# Altium schematic sheet BREEZE Pro TOF V1.SchDoc
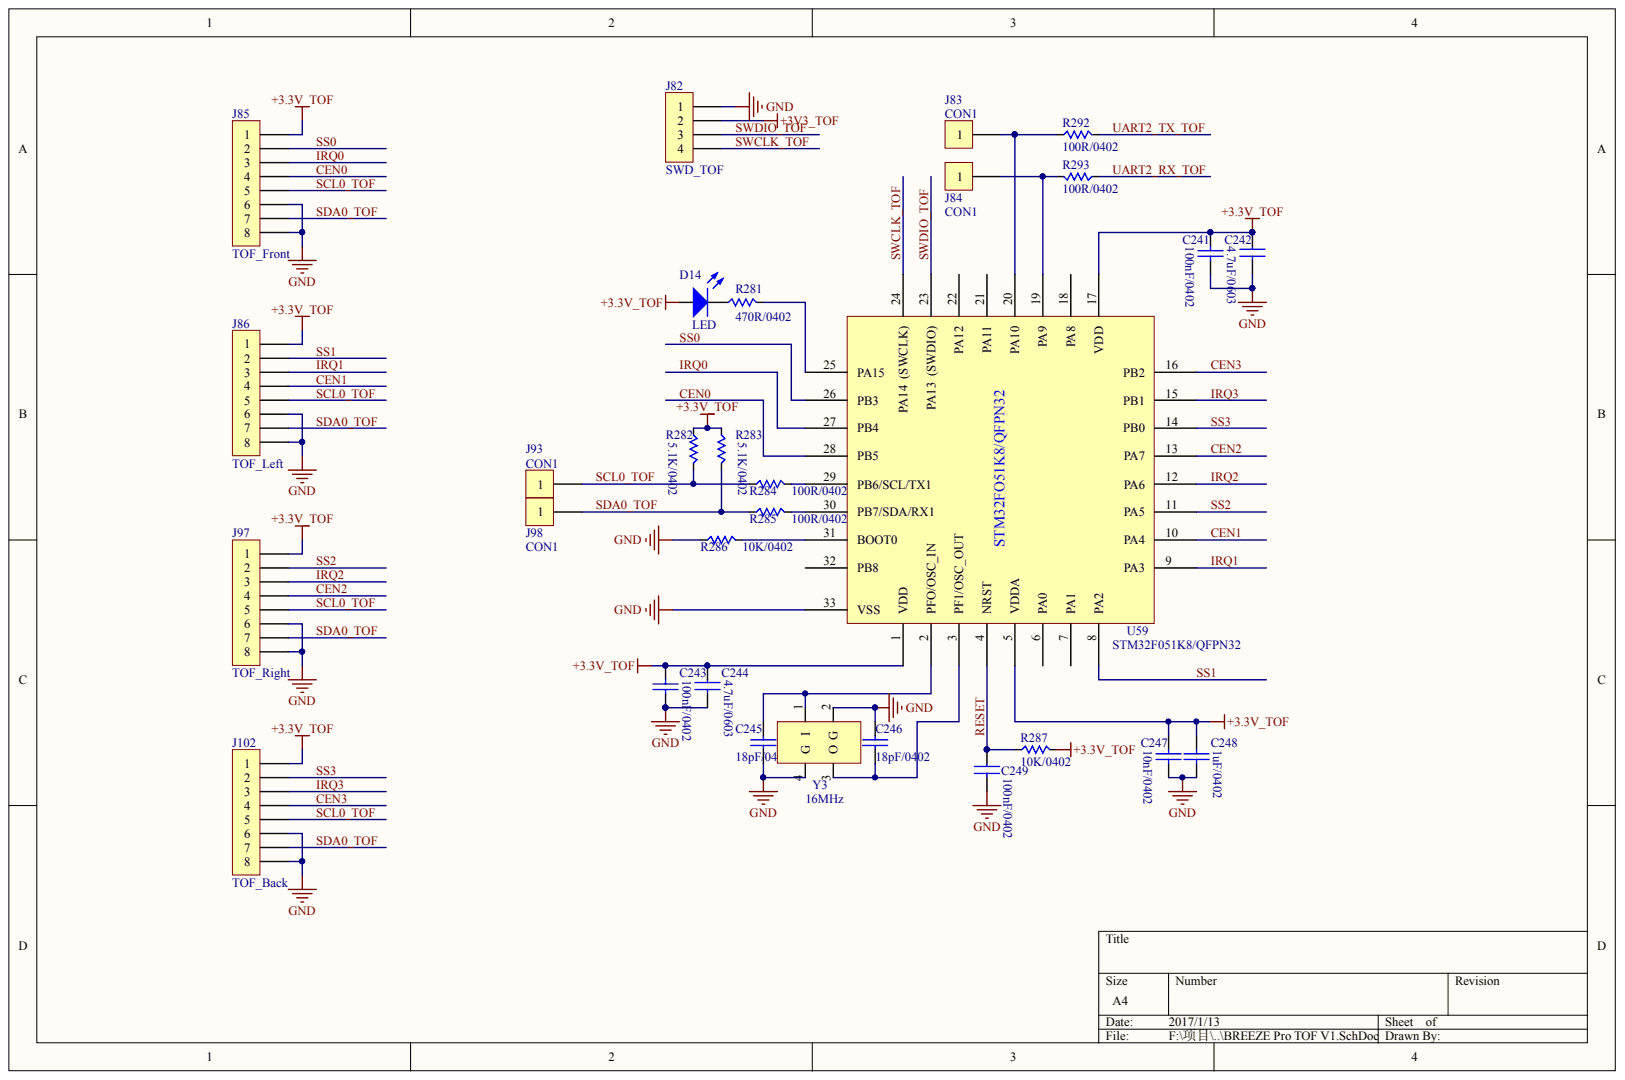
[diagram p0: BREEZE Pro TOF V1.SchDoc]

## Parts
C241  100nF/0402
C242  4.7uF/0603
C243  100nF/0402
C244  4.7uF/0603
C245  18pF/0402
C246  18pF/0402
C247  10nF/0402
C248  1uF/0402
C249  100nF/0402
D14  LED
J82  SWD_TOF
J83  CON1
J84  CON1
J85  TOF_Front
J86  TOF_Left
J93  CON1
J97  TOF_Right
J98  CON1
J102  TOF_Back
R281  470R/0402
R282  5.1K/0402
R283  5.1K/0402
R284  100R/0402
R285  100R/0402
R286  10K/0402
R287  10K/0402
R292  100R/0402
R293  100R/0402
U59  STM32F051K8/QFPN32
Y3  16MHz

## Nets
+3.3V_TOF: C241.1 C242.1 C243.1 C244.1 C247.1 C248.1 D14.1 J85.1 J86.1 J97.1 J102.1 R282.1 R283.1 R287.1 U59.1(VDD) U59.5(VDDA) U59.17(VDD)
+3V3_TOF: J82.2
GND: C241.2 C242.2 C243.2 C244.2 C245.2 C246.1 C247.2 C248.2 C249.2 J82.1 J85.6 J85.8 J86.6 J86.8 J97.6 J97.8 J102.6 J102.8 R286.2 U59.33(VSS) Y3.2(G) Y3.4(G)
CEN0: J85.4 U59.28(PB5)
CEN1: J86.4 U59.10(PA4)
CEN2: J97.4 U59.13(PA7)
CEN3: J102.4 U59.16(PB2)
IRQ0: J85.3 U59.27(PB4)
IRQ1: J86.3 U59.9(PA3)
IRQ2: J97.3 U59.12(PA6)
IRQ3: J102.3 U59.15(PB1)
RESET: C249.1 R287.2 U59.4(NRST)
SCL0_TOF: J85.5 J86.5 J93.1 J97.5 J102.5 R282.2 R284.2
SDA0_TOF: J85.7 J86.7 J97.7 J98.1 J102.7 R283.2 R285.2
SS0: J85.2 U59.26(PB3)
SS1: J86.2 U59.8(PA2)
SS2: J97.2 U59.11(PA5)
SS3: J102.2 U59.14(PB0)
SWCLK_TOF: J82.4 U59.24((SWCLK))
SWDIO_TOF: J82.3 U59.23((SWDIO))
UART2_RX_TOF: R293.1
UART2_TX_TOF: R292.2
NetC245_1: C245.1 U59.2(PFO/OSC_IN) Y3.1(I)
NetC246_2: C246.2 U59.3(PF1/OSC_OUT) Y3.3(O)
NetD14_2: D14.2 R281.1
NetJ83_1: J83.1 R292.1 U59.20(PA10)
NetJ84_1: J84.1 R293.2 U59.19(PA9)
NetR281_2: R281.2 U59.25(PA15)
NetR284_1: R284.1 U59.29(PB6/SCL/TX1)
NetR285_1: R285.1 U59.30(PB7/SDA/RX1)
NetR286_1: R286.1 U59.31(BOOT0)

Not connected: U59 6(PA0) 7(PA1) 18(PA8) 21(PA11) 22(PA12) 32(PB8)

## Library data of the parts
C242 C244 C249 = (no symbol name): Text Field1: 20; Text Field2: 10
Y3 = (no symbol name): Text Field1: 60; Text Field2: 30
